# Altium schematic sheet MCU.SchDoc
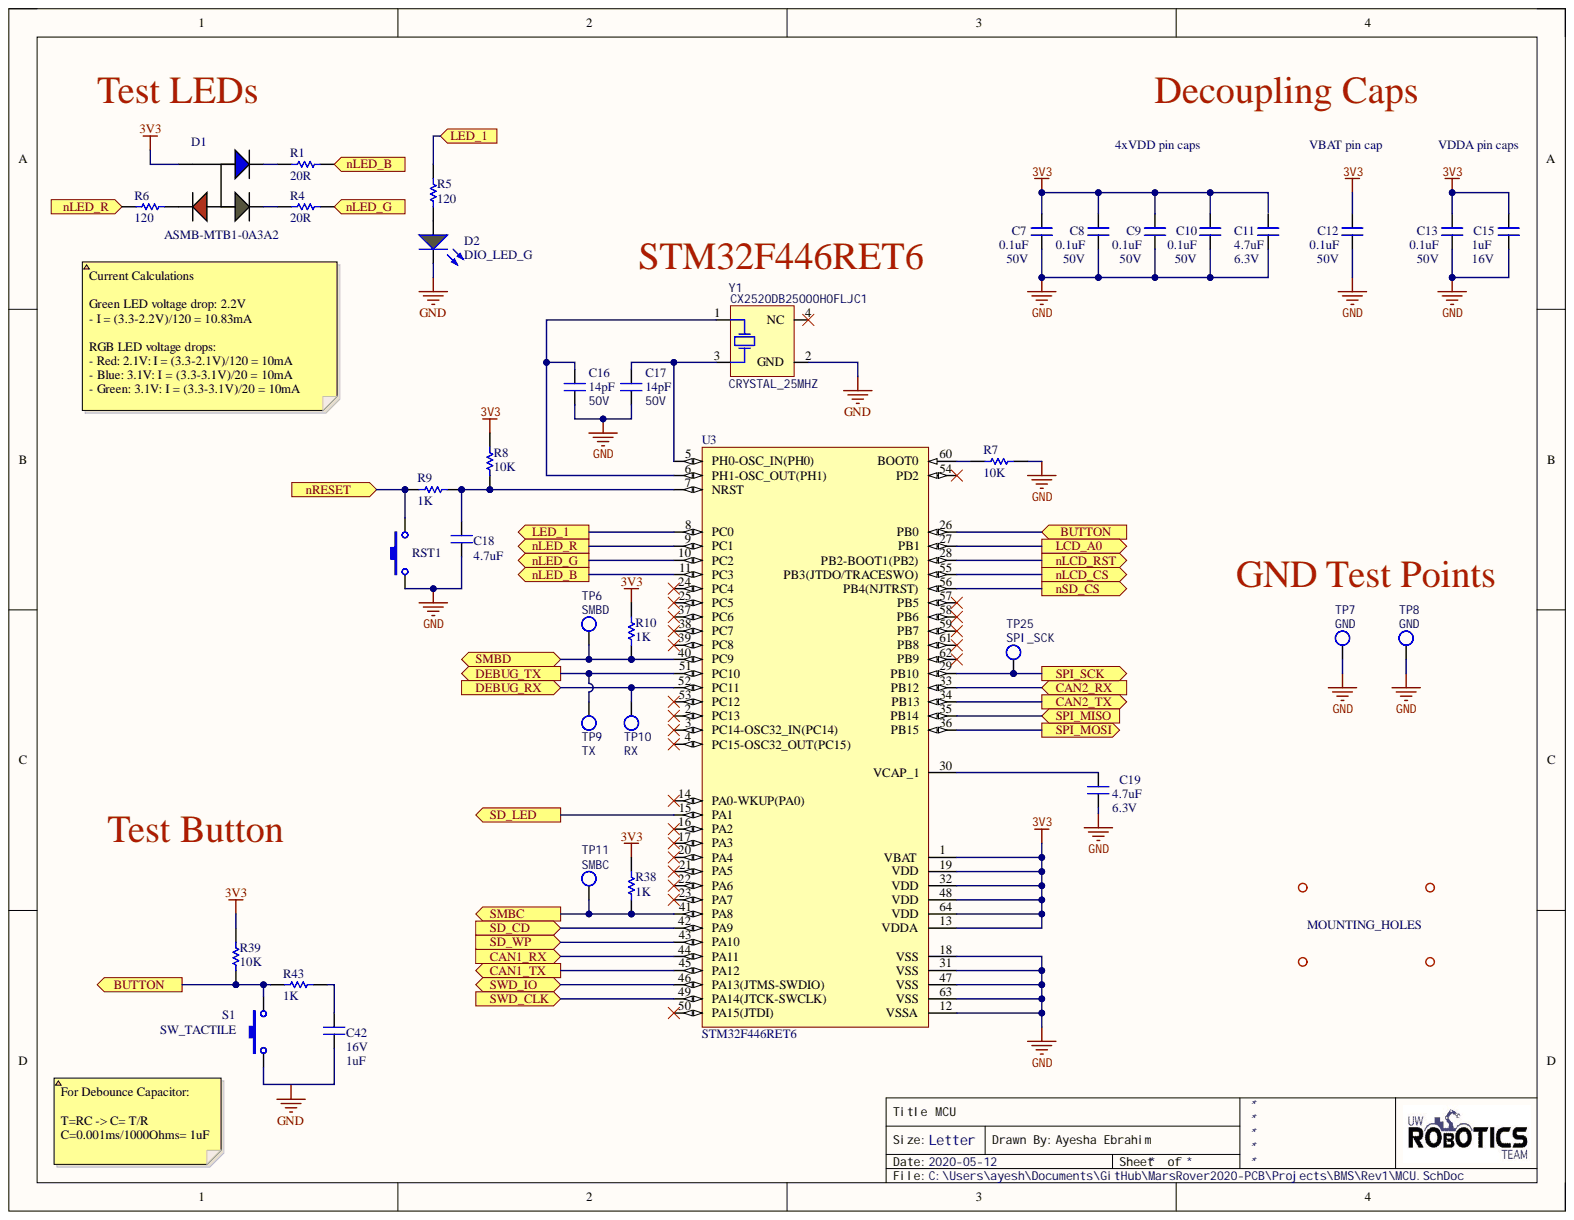
[diagram p0: MCU.SchDoc]

## Parts
C7  value 0.1uF  Voltage Rating: 50V  [CAPC2012X140N]  (hidden comment: CAP_0.1uF_50V_0805)
C8  value 0.1uF  Voltage Rating: 50V  [CAPC2012X140N]  (hidden comment: CAP_0.1uF_50V_0805)
C9  value 0.1uF  Voltage Rating: 50V  [CAPC2012X140N]  (hidden comment: CAP_0.1uF_50V_0805)
C10  value 0.1uF  Voltage Rating: 50V  [CAPC2012X140N]  (hidden comment: CAP_0.1uF_50V_0805)
C11  value 4.7uF  Voltage Rating: 6.3V  [CAPC0603(1608)55_N]  (hidden comment: CAP_4.7uF_0603)
C12  value 0.1uF  Voltage Rating: 50V  [CAPC2012X140N]  (hidden comment: CAP_0.1uF_50V_0805)
C13  value 0.1uF  Voltage Rating: 50V  [CAPC2012X140N]  (hidden comment: CAP_0.1uF_50V_0805)
C15  value 1uF  Voltage Rating: 16V  [CAPC0603(1608)55_N]  (hidden comment: CAP_1uF_16V_0603)
C16  value 14pF  Capacitance: 14pF  Voltage Rating: 50V  [CAPC0603(1608)55_N]  (hidden comment: CL10C140JB8NNNC)
C17  value 14pF  Capacitance: 14pF  Voltage Rating: 50V  [CAPC0603(1608)55_N]  (hidden comment: CL10C140JB8NNNC)
C18  value 4.7uF  [CAPC0603(1608)55_N]  (hidden comment: CAP_4.7uF_0603)
C19  value 4.7uF  Voltage Rating: 6.3V  [CAPC0603(1608)55_N]  (hidden comment: CAP_4.7uF_0603)
C42  value 1uF  Voltage Rating: 16V  [CAPC0603(1608)55_N]  (hidden comment: CAP_1uF_16V_0603)
D1  Manufacturer Part Number 1: ASMB-MTB1-0A3A2  [LED_RGB]  (hidden comment: LED_RGB)
D2  DIO_LED_G  [LED_0805_LINE]
MH1  MOUNTING_HOLES  [MOUNTING_HOLE]
MH2  MOUNTING_HOLES  [MOUNTING_HOLE]
MH3  MOUNTING_HOLES  [MOUNTING_HOLE]
MH4  MOUNTING_HOLES  [MOUNTING_HOLE]
R1  value 20R  [RESC0603(1608)_N]  (hidden comment: RES_20R_0603)
R4  value 20R  [RESC0603(1608)_N]  (hidden comment: RES_20R_0603)
R5  120  [RESC2012X60N]
R6  120  [RESC2012X60N]
R7  value 10K  Resistance: 10K  [RESC2012X60N]  (hidden comment: RES_10K_0805)
R8  value 10K  Resistance: 10K  [RESC2012X60N]  (hidden comment: RES_10K_0805)
R9  value 1K  Resistance: 1K  [RESC2012X60N]  (hidden comment: RES_1K_0805)
R10  value 1K  Resistance: 1K  [RESC2012X60N]  (hidden comment: RES_1K_0805)
R38  value 1K  Resistance: 1K  [RESC2012X60N]  (hidden comment: RES_1K_0805)
R39  value 10K  Resistance: 10K  [RESC2012X60N]  (hidden comment: RES_10K_0805)
R43  value 1K  Resistance: 1K  [RESC2012X60N]  (hidden comment: RES_1K_0805)
RST1  SW_PWR  [PTS830GM140]  (value not drawn)
S1  SW_TACTILE  [B3U-1100P]
TP6  SMBD  [TESTPOINT_LOOP]
TP7  GND  [TESTPOINT_LOOP]
TP8  GND  [TESTPOINT_LOOP]
TP9  TX  [TESTPOINT_LOOP]
TP10  RX  [TESTPOINT_LOOP]
TP11  SMBC  [TESTPOINT_LOOP]
TP25  SPI_SCK  [TESTPOINT_LOOP]
U3  STM32F446RET6  [STM-LQFP64_L]
Y1  CRYSTAL_25MHZ  Manufacturer Part Number 1: CX2520DB25000H0FLJC1

## Ports
BUTTON, CAN1_RX, CAN1_TX, CAN2_RX, CAN2_TX, DEBUG_RX, DEBUG_TX, LCD_A0, LED_1, nLCD_CS, nLCD_RST, nLED_B, nLED_G, nLED_R, nRESET, nSD_CS, SD_CD, SD_LED, SD_WP, SMBC, SMBD, SPI_MISO, SPI_MOSI, SPI_SCK, SWD_CLK, SWD_IO

## Nets
3V3: C7.2 C8.2 C9.2 C10.2 C12.2 C13.2 C15.2 D1.4 R8.2 R10.2 R38.2 R39.2 U3.1(VBAT) U3.13(VDDA) U3.19(VDD) U3.32(VDD) U3.48(VDD) U3.64(VDD)
GND: C7.1 C8.1 C9.1 C10.1 C11.1 C12.1 C13.1 C15.1 C16.1 C17.1 C18.1 C19.1 D2.2 R7.2 RST1.1 TP7.1 TP8.1 U3.12(VSSA) U3.18(VSS) U3.31(VSS) U3.47(VSS) U3.63(VSS) Y1.2(GND)
BUTTON: R39.1 R43.1 S1.1 U3.26(PB0) ; port BUTTON
CAN1_RX: U3.44(PA11) ; port CAN1_RX
CAN1_TX: U3.45(PA12) ; port CAN1_TX
CAN2_RX: U3.33(PB12) ; port CAN2_RX
CAN2_TX: U3.34(PB13) ; port CAN2_TX
DEBUG_RX: TP10.1 U3.52(PC11) ; port DEBUG_RX
DEBUG_TX: TP9.1 U3.51(PC10) ; port DEBUG_TX
LCD_A0: U3.27(PB1) ; port LCD_A0
LED_1: R5.2 U3.8(PC0) ; port LED_1
nLCD_CS: U3.55(PB3(JTDO/TRACESWO)) ; port nLCD_CS
nLCD_RST: U3.28(PB2-BOOT1(PB2)) ; port nLCD_RST
nLED_B: R1.2 U3.11(PC3) ; port nLED_B
nLED_G: R4.2 U3.10(PC2) ; port nLED_G
nLED_R: R6.1 U3.9(PC1) ; port nLED_R
nRESET: R9.1 RST1.2 ; port nRESET
nSD_CS: U3.56(PB4(NJTRST)) ; port nSD_CS
SD_CD: U3.42(PA9) ; port SD_CD
SD_LED: U3.15(PA1) ; port SD_LED
SD_WP: U3.43(PA10) ; port SD_WP
SMBC: R38.1 TP11.1 U3.41(PA8) ; port SMBC
SMBD: R10.1 TP6.1 U3.40(PC9) ; port SMBD
SPI_MISO: U3.35(PB14) ; port SPI_MISO
SPI_MOSI: U3.36(PB15) ; port SPI_MOSI
SPI_SCK: TP25.1 U3.29(PB10) ; port SPI_SCK
SWD_CLK: U3.49(PA14(JTCK-SWCLK)) ; port SWD_CLK
SWD_IO: U3.46(PA13(JTMS-SWDIO)) ; port SWD_IO
NetC16_2: C16.2 U3.6(PH1-OSC_OUT(PH1)) Y1.1
NetC17_2: C17.2 U3.5(PH0-OSC_IN(PH0)) Y1.3
NetC18_2: C18.2 R8.1 R9.2 U3.7(NRST)
NetC19_2: C19.2 U3.30(VCAP_1)
NetC42_1: C42.1 S1.2
NetC42_2: C42.2 R43.2
NetD1_1: D1.1 R6.2
NetD1_2: D1.2 R4.1
NetD1_3: D1.3 R1.1
NetD2_1: D2.1 R5.1
NetR7_1: R7.1 U3.60(BOOT0)

Not connected: C11 2; U3 2(PC13) 3(PC14-OSC32_IN(PC14)) 4(PC15-OSC32_OUT(PC15)) 14(PA0-WKUP(PA0)) 16(PA2) 17(PA3) 20(PA4) 21(PA5) 22(PA6) 23(PA7) 24(PC4) 25(PC5) 37(PC6) 38(PC7) 39(PC8) 50(PA15(JTDI)) 53(PC12) 54(PD2) 57(PB5) 58(PB6) 59(PB7) 61(PB8) 62(PB9); Y1 4(NC)

## Library data of the parts
C7-C10 C12 C13 = CAP_0.1uF_50V_0805: CAP CER 0.1UF 50V X7R 0805; Manufacturer 1: Murata Electronics; Manufacturer Part Number 1: GCD21BR71H104KA01L; Capacitance: 100nF; Tolerance: 10%; Case Code (Imperial): 0805; Case Code (Metric): 2012; Case/Package: 0805; Component Kind: Standard; ComponentLink1Description: https://datasheet.ciiva.com/29537/c03e-29537701.pdf?src-supplier=Digi-Key; ComponentLink1URL: https://datasheet.ciiva.com/29537/c03e-29537701.pdf?src-supplier=Digi-Key; Depth: 1.25mm; Dielectric: X7R; Height: 1.25mm; Length: 2mm; Max Operating Temperature: 125°C; Min Operating Temperature: -55°C; Mount: Surface Mount; Ratings: AEC-Q200; Supplier 1: Digi-Key; Supplier Part Number 1: 490-11955-1-ND; Termination: SMD/SMT; Thickness: 0.049inch; Width: 0.049inch; Library Name: UWRT_Schematic_Library_2020.SchLib
C11 C18 C19 = CAP_4.7uF_0603: CAP CER 4.7UF 6.3V X5R 0603; Manufacturer 1: Yageo; Manufacturer Part Number 1: CC0603KRX5R5BB475; Component Kind: Standard; Dimensions: 0.063" L x 0.031" W (1.60mm x 0.80mm); Mount: Surface Mount; Package: 0603 (1608 Metric); Signal Integrity: Cap; Simulation: CAP; Supplier 1: Digi-Key; Supplier Part Number 1: 311-1521-1-ND; Temperature Coefficient: X5R; Library Name: UWRT_Schematic_Library_2020.SchLib
  C18: Voltage Rating: 6.3V
C15 C42 = CAP_1uF_16V_0603: CAP CER 1UF 16V Y5V 0603; Manufacturer 1: Yageo; Manufacturer Part Number: CC0603ZRY5V7BB105; Component Kind: Standard; Dimensions: 0.063" L x 0.031" W (1.60mm x 0.80mm); Mount: Surface Mount; Package: 0603 (1608 Metric); Signal Integrity: Cap; Simulation: CAP; Supplier 1: Digi-Key; Supplier Part Number 1: 311-1372-1-ND; Temperature Coefficient: Y5V; Library Name: UWRT_Schematic_Library_2020.SchLib
C16 C17 = CL10C140JB8NNNC: Cap Ceramic 14pF 50V C0G 5% SMD 0603 125C Cardboard T/R; Manufacturer 1: Samsung; Case Code (Imperial): 0603; Case Code (Metric): 1608; Case/Package: 0603; Component Kind: Standard; ComponentLink1Description: https://datasheet.ciiva.com/25782/cl10c140jb8nnnc-25782947.pdf?src-supplier=Digi-Key; ComponentLink1URL: https://datasheet.ciiva.com/25782/cl10c140jb8nnnc-25782947.pdf?src-supplier=Digi-Key; Depth: 0.8mm; Dielectric: C0G; Height: 0.8mm; Length: 1.6mm; Manufacturer Part Number 1: CL10C140JB8NNNC; Max Operating Temperature: 125°C; Min Operating Temperature: -55°C; Mount: Surface Mount; Package Quantity: 1; Packaging: Tape and Reel; Pricing 1: 1=0.10000, 10=0.06700, 100=0.02980, 500=0.02130, 1000=0.01673 (USD); Radiation Hardening: No; RoHS: Yes; Stock 1: 187088; Supplier 1: Digi-Key; Supplier Part Number 1: 1276-2187-1-ND; Thickness: 0.035inch; Tolerance: 5%; Voltage Rating (DC): 50V; Width: 0.031inch; Library Name: UWRT_Schematic_Library_2020.SchLib
D1 = LED_RGB: LED RGB DIFFUSED 4PLCC SMD; Manufacturer 1: Broadcom; Color: Blue,Green,Red; Dominant Wavelength: 470nm; Forward Voltage: 3.1V; Lens Color: White; Lens Style: Round; Luminous Intensity: 350mcd; Test Current: 20mA; Viewing Angle: 115°; Case/Package: PLCC; Component Kind: Standard; ComponentLink1Description: http://www.farnell.com/datasheets/2111106.pdf?src-supplier=Farnell; ComponentLink1URL: http://www.farnell.com/datasheets/2111106.pdf?src-supplier=Farnell; ComponentLink2Description: https://docs.broadcom.com/docs/AV02-4194EN?src-supplier=Digi-Key; ComponentLink2URL: https://docs.broadcom.com/docs/AV02-4194EN?src-supplier=Digi-Key; ComponentLink3Description: http://www.farnell.com/datasheets/1801564.pdf?src-supplier=Element14; ComponentLink3URL: http://www.farnell.com/datasheets/1801564.pdf?src-supplier=Element14; ComponentLink4Description: http://www.farnell.com/datasheets/2111106.pdf?src-supplier=Newark; ComponentLink4URL: http://www.farnell.com/datasheets/2111106.pdf?src-supplier=Newark; Height: 1.9mm; Length: 3.2mm; Lens Transparency: Diffused; Mount: Surface Mount; Number of Pins: 4; Packaging: Cut Tape; Pricing 1: 1=0.60000, 10=0.47100, 100=0.30210, 500=0.25980, 1000=0.20059 (USD); REACH SVHC: No SVHC; RoHS: Yes; Stock 1: 142531; Supplier 1: Digi-Key; Supplier Part Number 1: 516-3280-1-ND; Width: 2.8mm; Library Name: UWRT_Schematic_Library_2020.SchLib
D2 = DIO_LED_G: LED GREEN DIFFUSED 0805 SMD; Manufacturer 1: OSRAM; Manufacturer Part Number 1: LG R971-KN-1; Forward Voltage Drop: 2.2V; Component Kind: Standard; Mount: Surface Mount; Package: 0805 (2012 Metric); Simulation: LED1; Supplier 1: Digi-Key; Supplier Part Number 1: 475-1410-1-ND; Library Name: UWRT_Schematic_Library_2020.SchLib
MH1-MH4 = MOUNTING_HOLES: 6cm x 6cm; Component Kind: Standard; Library Name: UWRT_Schematic_Library_2020.SchLib
R1 R4 = RES_20R_0603: RES SMD 20 OHM 5% 1/10W 0603; Manufacturer 1: Yageo; Manufacturer Part Number 1: RC0603JR-0720RL; Component Kind: Standard; Dimensions: 0.063" L x 0.031" W (1.60mm x 0.80mm); Mount: Surface Mount; Package: 0603 (1608 Metric); Signal Integrity: Res; Simulation: RESISTOR; Supplier 1: Digi-Key; Supplier Part Number 1: 311-20GRCT-ND; Tolerance: 5%; Library Name: UWRT_Schematic_Library_2020.SchLib
R5 R6 = RES_120R_0805: RES SMD 120 OHM 1% 1/8W 0805; Manufacturer 1: Vishay; Manufacturer Part Number 1: CRCW0805120RFKEA; Power Rating: 125mW; Voltage Rating: 150V; Case Code (Imperial): 0805; Case Code (Metric): 2012; Component Kind: Standard; ComponentLink10Description: https://datasheet.ciiva.com/16788/70201119-16788154.pdf?src-supplier=Allied+Electronics; ComponentLink10URL: https://datasheet.ciiva.com/16788/70201119-16788154.pdf?src-supplier=Allied+Electronics; ComponentLink11Description: https://datasheet.ciiva.com/7777/1720486-7777567.pdf?src-supplier=Element14; ComponentLink11URL: https://datasheet.ciiva.com/7777/1720486-7777567.pdf?src-supplier=Element14; ComponentLink1Description: https://datasheet.ciiva.com/11810/0900766b80d98b26-11810477.pdf?src-supplier=RSComponents; ComponentLink1URL: https://datasheet.ciiva.com/11810/0900766b80d98b26-11810477.pdf?src-supplier=RSComponents; ComponentLink2Description: https://datasheet.ciiva.com/3258/dcrcwe3-3258069.pdf?src-supplier=Avnet; ComponentLink2URL: https://datasheet.ciiva.com/3258/dcrcwe3-3258069.pdf?src-supplier=Avnet; ComponentLink3Description: https://datasheet.ciiva.com/26317/fld-crcw-e3-data-en-26317334.pdf?src-supplier=Distrelec; ComponentLink3URL: https://datasheet.ciiva.com/26317/fld-crcw-e3-data-en-26317334.pdf?src-supplier=Distrelec; ComponentLink4Description: https://datasheet.ciiva.com/2467/dcrcwe3-2467512.pdf?src-supplier=Digi-Key; ComponentLink4URL: https://datasheet.ciiva.com/2467/dcrcwe3-2467512.pdf?src-supplier=Digi-Key; ComponentLink5Description: https://datasheet.ciiva.com/2467/dcrcwe3-2467514.pdf?src-supplier=Digi-Key; ComponentLink5URL: https://datasheet.ciiva.com/2467/dcrcwe3-2467514.pdf?src-supplier=Digi-Key; ComponentLink6Description: https://datasheet.ciiva.com/16211/dcrcwe3-16211931.pdf?src-supplier=Future+Electronics; ComponentLink6URL: https://datasheet.ciiva.com/16211/dcrcwe3-16211931.pdf?src-supplier=Future+Electronics; ComponentLink7Description: https://datasheet.ciiva.com/2467/dcrcwe3-2467513.pdf?src-supplier=Digi-Key; ComponentLink7URL: https://datasheet.ciiva.com/2467/dcrcwe3-2467513.pdf?src-supplier=Digi-Key; ComponentLink8Description: https://datasheet.ciiva.com/16852/dcrcwe3-16852841.pdf?src-supplier=Avnet+Europe; ComponentLink8URL: https://datasheet.ciiva.com/16852/dcrcwe3-16852841.pdf?src-supplier=Avnet+Europe; ComponentLink9Description: https://datasheet.ciiva.com/7777/1697337-7777354.pdf?src-supplier=Element14; ComponentLink9URL: https://datasheet.ciiva.com/7777/1697337-7777354.pdf?src-supplier=Element14; Mount: Surface Mount; Resistance: 120; Supplier 1: Digi-Key; Supplier Part Number 1: 541-120CCT-ND; Library Name: UWRT_Schematic_Library_2020.SchLib
R7 R8 R39 = RES_10K_0805: RES SMD 10K OHM 1% 1/8W 0805; Manufacturer 1: Yageo; Manufacturer Part Number 1: RC0805FR-0710KL; Power Rating: 125mW; Case Code (Imperial): 0805; Case Code (Metric): 2012; Component Kind: Standard; Max Operating Temperature: 155°C; Min Operating Temperature: -55°C; Supplier 1: Digi-Key; Supplier Part Number 1: 311-10.0KCRCT-ND; Termination: SMD/SMT; Tolerance: 1%; Library Name: UWRT_Schematic_Library_2020.SchLib
R9 R10 R38 R43 = RES_1K_0805: RES SMD 1K OHM 1% 1/8W 0805; Manufacturer 1: Yageo; Manufacturer Part Number 1: RC0805FR-071KL; Power Rating: 125mW; Case Code (Imperial): 0805; Case Code (Metric): 2012; Component Kind: Standard; Max Operating Temperature: 155°C; Min Operating Temperature: -55°C; Supplier 1: Digi-Key; Supplier Part Number 1: 311-1.00KCRCT-ND; Termination: SMD/SMT; Tolerance: 1%; Library Name: UWRT_Schematic_Library_2020.SchLib
RST1 = SW_PWR: Power Switch (Red); Manufacturer 1: C&K; Manufacturer Part Number 1: PTS830GM140 SMTR LFS; Component Kind: Standard; ComponentLink1Description: Datasheet; ComponentLink1URL: https://www.ckswitches.com/media/1478/pts830.pdf; Mount: Surface Mount; Supplier 1: Digi-Key; Supplier Part Number 1: CKN10587CT-ND; Library Name: UWRT_Schematic_Library_2020.SchLib
S1 = SW_TACTILE: Tactile Switch (Grey); Manufacturer 1: Omron Electronics Inc-EMC Div; Component Kind: Standard; Manufacturer Part Number 1: B3U-1100P; Mount: Surface Mount; Supplier 1: Digi-Key; Supplier Part Number 1: SW1021CT-ND; Library Name: UWRT_Schematic_Library_2020.SchLib
TP6-TP11 TP25 = TESTPOINT_LOOP: Loop testpoint through-hole pad; Manufacturer 1: Keystone Electronics; Manufacturer Part Number 1: 5002; Component Kind: Standard; Mount: Through Hole; Supplier 1: Digi-Key; Supplier Part Number 1: 36-5002-ND; Library Name: UWRT_Schematic_Library_2020.SchLib
U3 = MCU_STM32F446RET6: ARM Cortex-M4 32-bit MCU+FPU, 512 KB Flash, 128 KB Internal RAM, 50 I/Os, 64-Pin LQFP, -40 to 85 degC, Tray; D/A Converter: 2; FLASH Size (Prog) (kB): 512; I/Os (High Current): 50; Internal RAM Size (kB): 128; Operating Frequency(F) (Processor speed) (MHz): 180; Supply Voltage(Vcc) min (V): 1.7; Component Kind: Standard; ComponentLink1Description: Manufacturer URL; ComponentLink1URL: http://www.st.com/; ComponentLink2Description: Datasheet; ComponentLink2URL: http://www.st.com/st-web-ui/static/active/en/resource/technical/document/datasheet/DM00141306.pdf; Core: ARM Cortex-M4; DatasheetVersion: Rev. 6; Mounting Technology: Surface Mount; Package Description: LQFP64; Package Reference: 64-Pin Low-profile Quad Flat Package, Body 10 x 10 mm, Pitch 0.5 mm; Packing: Tray; Supplier 1: Digi-Key; Supplier Part Number 1: 497-15376-ND; Library Name: UWRT_Schematic_Library_2020.SchLib
Y1 = CRYSTAL_25MHZ: CRYSTAL 25.0000MHZ 12PF SMD; Manufacturer 1: Kyocera AVX; ESR (Equivalent Series Resistance): 80R; Frequency: 25MHz; Frequency Stability: 15ppm; Frequency Tolerance: 10ppm; Case/Package: SMD/SMT; Component Kind: Standard; ComponentLink1Description: https://media.digikey.com/pdf/Data%20Sheets/AVX%20PDFs/CX2520DB_USY1M-H1-16429-00_Spec.pdf?src-supplier=Digi-Key; ComponentLink1URL: https://media.digikey.com/pdf/Data%20Sheets/AVX%20PDFs/CX2520DB_USY1M-H1-16429-00_Spec.pdf?src-supplier=Digi-Key; Height: 0.022inch; Length: 0.098inch; Load Capacitance: 12pF; Max Operating Temperature: 85°C; Min Operating Temperature: -30°C; Mount: Surface Mount; Packaging: Tape and Reel; Pricing 1: 1=0.72000, 10=0.63300, 50=0.59120, 100=0.52250, 500=0.49500, 1000=0.41250 (USD); Ratings: AEC-Q200; RoHS: Yes; Stock 1: 11741; Supplier 1: Digi-Key; Supplier Part Number 1: 1253-1724-1-ND; Width: 0.079inch; Library Name: UWRT_Schematic_Library_2020.SchLib
